# Altium schematic sheet DVR8421.SchDoc
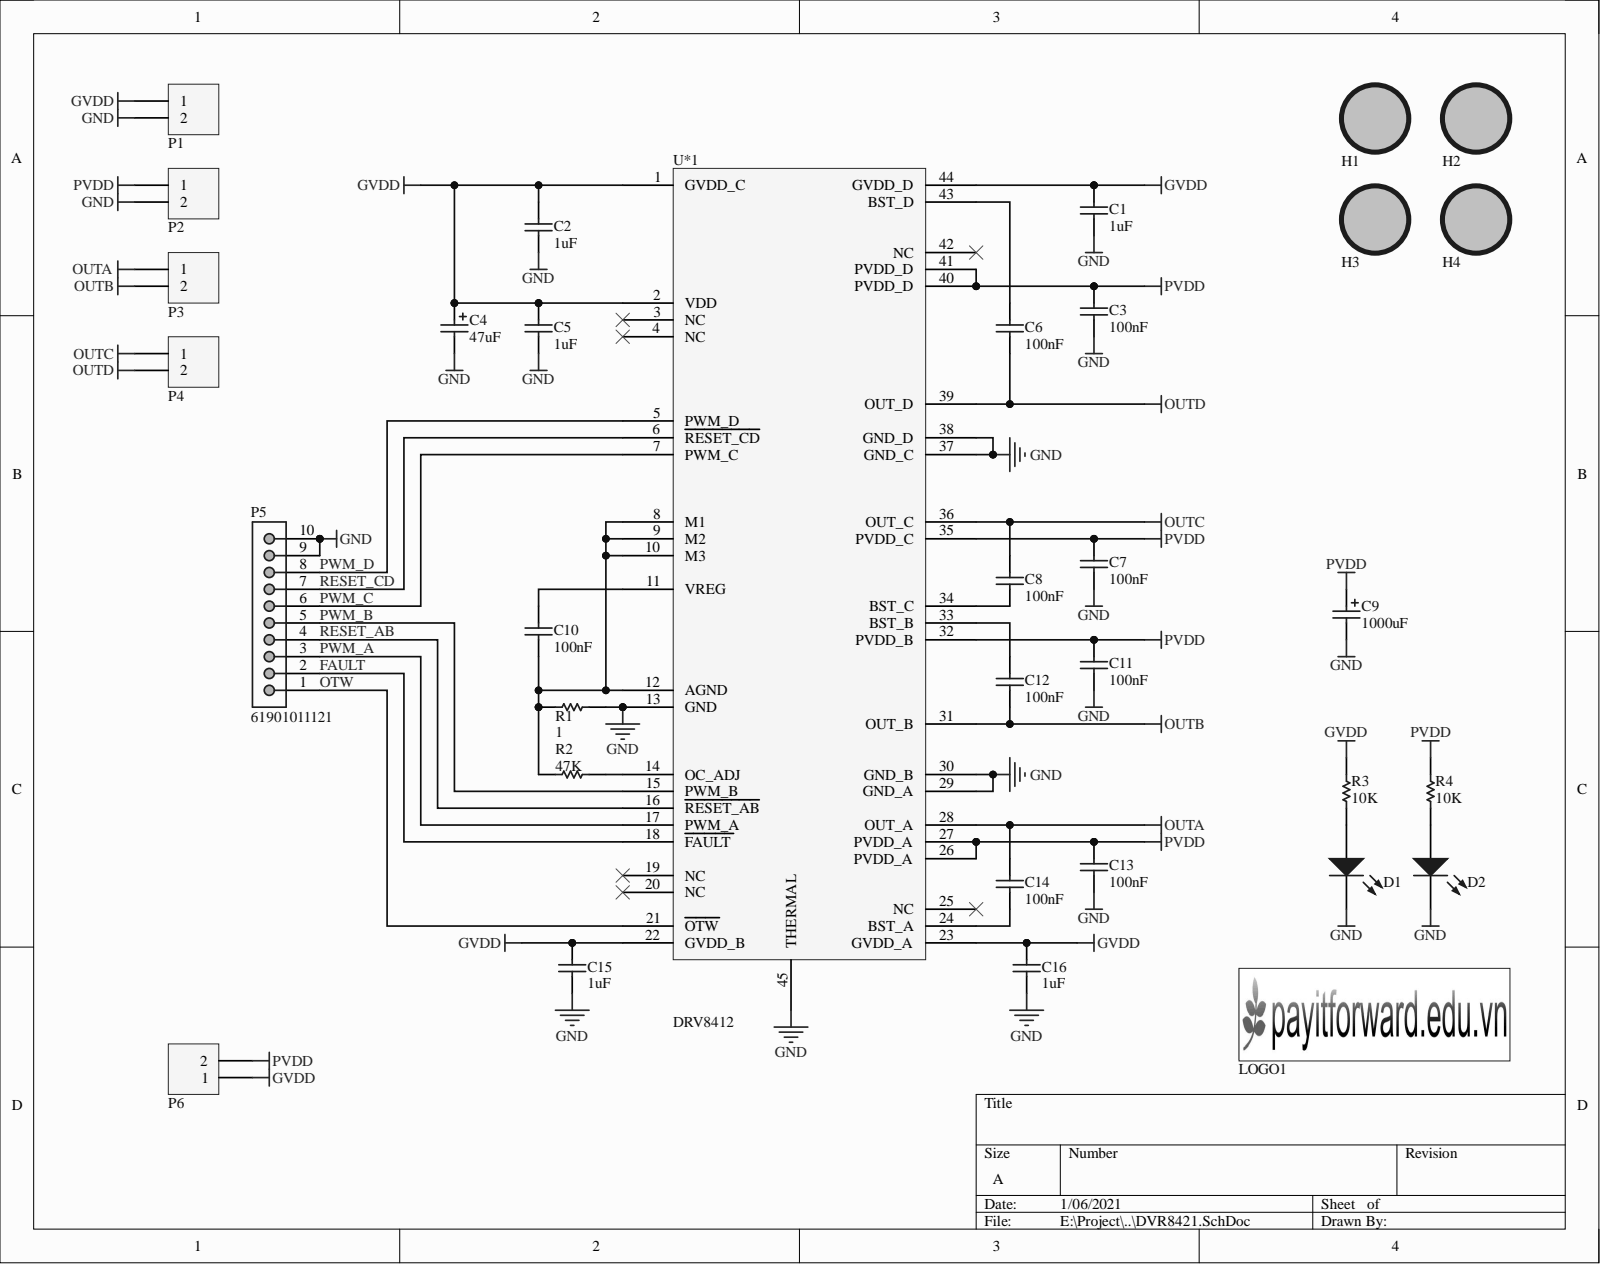
[diagram p0: DVR8421.SchDoc]

## Parts
C1  value 1uF  [CAP-SM-0603]  (hidden comment: Cap Non-Pol SMD)
C2  value 1uF  [CAP-SM-0603]  (hidden comment: Cap Non-Pol SMD)
C3  value 100nF  [CAP-SM-0603]  (hidden comment: Cap Non-Pol SMD)
C4  value 47uF  [CAP ALU SIZE D]  (hidden comment: Cap Pol SMD)
C5  value 1uF  [CAP-SM-0603]  (hidden comment: Cap Non-Pol SMD)
C6  value 100nF  [CAP-SM-0603]  (hidden comment: Cap Non-Pol SMD)
C7  value 100nF  [CAP-SM-0603]  (hidden comment: Cap Non-Pol SMD)
C8  value 100nF  [CAP-SM-0603]  (hidden comment: Cap Non-Pol SMD)
C9  value 1000uF  [CP2/5]  (hidden comment: Cap Pol)
C10  value 100nF  [CAP-SM-0603]  (hidden comment: Cap Non-Pol SMD)
C11  value 100nF  [CAP-SM-0603]  (hidden comment: Cap Non-Pol SMD)
C12  value 100nF  [CAP-SM-0603]  (hidden comment: Cap Non-Pol SMD)
C13  value 100nF  [CAP-SM-0603]  (hidden comment: Cap Non-Pol SMD)
C14  value 100nF  [CAP-SM-0603]  (hidden comment: Cap Non-Pol SMD)
C15  value 1uF  [CAP-SM-0603]  (hidden comment: Cap Non-Pol SMD)
C16  value 1uF  [CAP-SM-0603]  (hidden comment: Cap Non-Pol SMD)
D1  LED SMD  [LED_0603_Blue]  (value not drawn)
D2  LED SMD  [LED_0603_Blue]  (value not drawn)
H1  Hole  [LO KHOAN 3MM]  (value not drawn)
H2  Hole  [LO KHOAN 3MM]  (value not drawn)
H3  Hole  [LO KHOAN 3MM]  (value not drawn)
H4  Hole  [LO KHOAN 3MM]  (value not drawn)
LOGO1  LOGO PIF  [PIF LOGO SMALL TOP]  (value not drawn)
P1  Domino 2  [DOMINO SMALL 2]  (value not drawn)
P2  Domino 2  [DOMINO SMALL 2]  (value not drawn)
P3  Domino 2  [DOMINO SMALL 2]  (value not drawn)
P4  Domino 2  [DOMINO SMALL 2]  (value not drawn)
P5  61901011121  [61901011121]
P6  Header 2  [JP2]  (value not drawn)
R1  value 1  [RES-SM-0603]  (hidden comment: RESISTOR SMD)
R2  value 47K  [RES-SM-0603]  (hidden comment: RESISTOR SMD)
R3  value 10K  [RES-SM-0603]  (hidden comment: RESISTOR SMD)
R4  value 10K  [RES-SM-0603]  (hidden comment: RESISTOR SMD)
U*1  DRV8412  [TSOP64P810X150_HS-45N]

## Nets
GND: C1.1 C2.1 C3.1 C4.2 C5.1 C7.1 C9.2 C11.1 C13.1 C15.1 C16.1 D1.2 D2.2 P1.2 P2.2 P5.9 P5.10 R1.2 U*1.13(GND) U*1.29(GND_A) U*1.30(GND_B) U*1.37(GND_C) U*1.38(GND_D) U*1.45(THERMAL)
GVDD: C1.2 C2.2 C4.1 C5.2 C15.2 C16.2 P1.1 P6.1 R3.1 U*1.1(GVDD_C) U*1.2(VDD) U*1.22(GVDD_B) U*1.23(GVDD_A) U*1.44(GVDD_D)
OUTA: C14.2 P3.1 U*1.28(OUT_A)
OUTB: C12.1 P3.2 U*1.31(OUT_B)
OUTC: C8.2 P4.1 U*1.36(OUT_C)
OUTD: C6.1 P4.2 U*1.39(OUT_D)
PVDD: C3.2 C7.2 C9.1 C11.2 C13.2 P2.1 P6.2 R4.1 U*1.26(PVDD_A) U*1.27(PVDD_A) U*1.32(PVDD_B) U*1.35(PVDD_C) U*1.40(PVDD_D) U*1.41(PVDD_D)
FAULT: P5.2 U*1.18(FAULT)
OTW: P5.1 U*1.21(OTW)
PWM_A: P5.3 U*1.17(PWM_A)
PWM_B: P5.5 U*1.15(PWM_B)
PWM_C: P5.6 U*1.7(PWM_C)
PWM_D: P5.8 U*1.5(PWM_D)
RESET_AB: P5.4 U*1.16(RESET_AB)
RESET_CD: P5.7 U*1.6(RESET_CD)
NetC6_2: C6.2 U*1.43(BST_D)
NetC8_1: C8.1 U*1.34(BST_C)
NetC10_1: C10.1 R1.1 R2.1 U*1.8(M1) U*1.9(M2) U*1.10(M3) U*1.12(AGND)
NetC10_2: C10.2 U*1.11(VREG)
NetC12_2: C12.2 U*1.33(BST_B)
NetC14_1: C14.1 U*1.24(BST_A)
NetD1_1: D1.1 R3.2
NetD2_1: D2.1 R4.2
NetR2_2: R2.2 U*1.14(OC_ADJ)

Not connected: U*1 3(NC) 4(NC) 19(NC) 20(NC) 25(NC) 42(NC)

## Library data of the parts
C1-C3 C5-C8 C10-C16 = Cap Non-Pol SMD: Capacitor; Component Kind: Standard; Library Name: PIF_Library.IntLib
C4 = Cap Pol SMD: Polarized Capacitor (Radial); Component Kind: Standard; Library Name: PIF_Library.IntLib
C9 = Cap Pol: Polarized Capacitor (Radial); Component Kind: Standard; Library Name: PIF_Library.IntLib
D1 D2 = LED SMD: LED; Component Kind: Standard; Library Name: PIF_Library.IntLib
H1-H4 = Hole: Hole 3mm; Component Kind: Standard; Library Name: PIF_Library.IntLib
P1-P4 = Domino 2: Domino, 2-Pin; Component Kind: Standard; LatestRevisionDate: 17-Jul-2002; LatestRevisionNote: Re-released for DXP Platform.; Library Name: PIF_Library.IntLib; Publisher: Altium Limited
P5 = 61901011121: WR-WTB THT Male Vertical Locking Header, pitch 2.54mm, 10p; Contact Resistance: 20mOhm; Pin Number: 10; Rated Current: 3A; Withstanding Voltage: 1000VAC; Working Voltage: 250VAC; Component Kind: Standard; ComponentLink1Description: Manufacturer; ComponentLink1URL: http://www.we-online.de; ComponentLink2Description: Datasheet; ComponentLink2URL: https://www.we-online.com/catalog/datasheet/61901011121.pdf; Gender: Male; Library Name: 653008114822_Altium_WR-WTB_rev20a.IntLib; Mounting Technology: THT; Operating Temperature: -40 to +105degC; Packaging: Bag; Part Number: 61901011121; Pitch: 2.54mm; Published Date: January 2020; Type: Vertical; Publisher: Wurth Elektronik
P6 = Header 2: Header, 2-Pin; Component Kind: Standard; LatestRevisionDate: 17-Jul-2002; LatestRevisionNote: Re-released for DXP Platform.; Library Name: PIF_Library.IntLib; Publisher: Altium Limited
R1-R4 = RESISTOR SMD: Resistor; Component Kind: Standard; Library Name: PIF_Library.IntLib
U*1 = DRV8412: Manufacturer: Texas Instruments; Manufacturer P/N: DRV8412DDWR; Component Kind: Standard; ComponentLink1Description: Supplier 1 Link; ComponentLink1URL: https://www.mouser.vn/ProductDetail/Texas-Instruments/DRV8412DDWR/?qs=%2Fqzd9s%252BcLd4TfGj6lnLxVg%3D%3D; Supplier 1: Mouser; Supplier 1 P/N: 595-DRV8412DDWR; Library Name: MiclsLib.SchLib
LOGO1 = LOGO PIF: Component Kind: Standard; Library Name: PIF_Library.IntLib
